# Altium schematic sheet mcu_side.SchDoc
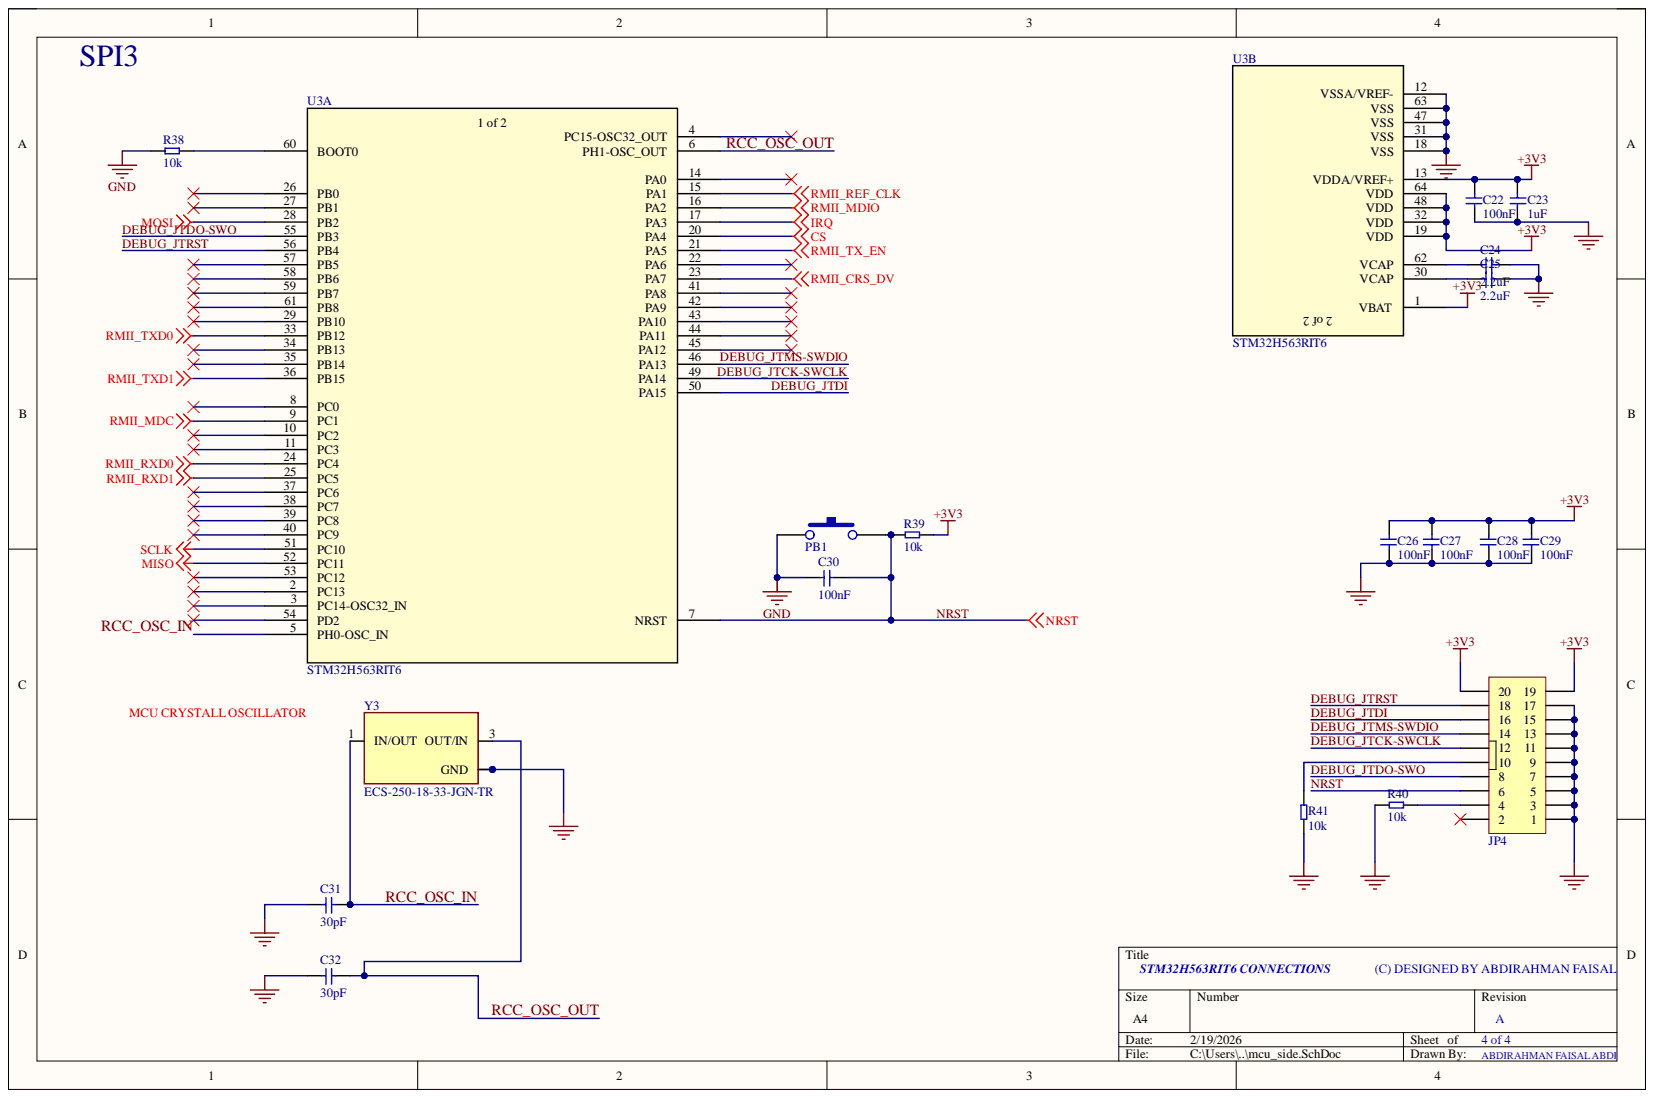
[diagram p0: mcu_side.SchDoc]

## Parts
C22  100nF  [C0603]
C23  1uF  [C0603]
C24  2.2uF  [C0603]
C25  2.2uF  [C0603]
C26  100nF  [C0603]
C27  100nF  [C0603]
C28  100nF  [C0603]
C29  100nF  [C0603]
C30  100nF  [C0603]
C31  30pF  [C0603]
C32  30pF  [C0603]
JP4  Box_Header 2X10  [Box_Header 2x10]  (value not drawn)
PB1  PUSH_BUTTON  [Push Button SPST]  (value not drawn)
R38  10k  [R0603]
R39  10k  [R0603]
R40  10k  [R0603]
R41  10k  [R0603]
U3  STM32H563RIT6  [LQFP64-10x10mm_STM32H563RIT6]  (parts A,B)
Y3  ECS-250-18-33-JGN-TR  [XTAL_ECS-250-18-33-JGN-TR]

## Nets
+3V3: C22.1 C23.2 C26.1 C27.1 C28.1 C29.1 JP4.19 JP4.20 R39.2 U3.1(VBAT) U3.13(VDDA/VREF+) U3.19(VDD) U3.32(VDD) U3.48(VDD) U3.64(VDD)
CS: U3.20(PA4)
GND: C22.2 C23.1 C24.2 C25.2 C26.2 C27.2 C28.2 C29.2 C30.1 C31.1 C32.1 JP4.1 JP4.3 JP4.5 JP4.7 JP4.9 JP4.11 JP4.13 JP4.15 JP4.17 PB1.1 R38.1 R40.1 R41.1 U3.12(VSSA/VREF-) U3.18(VSS) U3.31(VSS) U3.47(VSS) U3.63(VSS) Y3.2(GND) Y3.4
IRQ: U3.17(PA3)
MISO: U3.52(PC11)
MOSI: U3.28(PB2)
RMII_CRS_DV: U3.23(PA7)
RMII_MDC: U3.9(PC1)
RMII_MDIO: U3.16(PA2)
RMII_REF_CLK: U3.15(PA1)
RMII_RXD0: U3.24(PC4)
RMII_RXD1: U3.25(PC5)
RMII_TX_EN: U3.21(PA5)
RMII_TXD0: U3.33(PB12)
RMII_TXD1: U3.36(PB15)
SCLK: U3.51(PC10)
DEBUG_JTCK-SWCLK: JP4.12 U3.49(PA14)
DEBUG_JTDI: JP4.16 U3.50(PA15)
DEBUG_JTDO-SWO: JP4.8 U3.55(PB3)
DEBUG_JTMS-SWDIO: JP4.14 U3.46(PA13)
DEBUG_JTRST: JP4.18 U3.56(PB4)
NRST: C30.2 JP4.6 PB1.2 R39.1 U3.7(NRST)
RCC_OSC_IN: C31.2 U3.5(PH0-OSC_IN) Y3.1(IN/OUT)
RCC_OSC_OUT: C32.2 U3.6(PH1-OSC_OUT) Y3.3(OUT/IN)
NetC24_1: C24.1 U3.62(VCAP)
NetC25_1: C25.1 U3.30(VCAP)
NetJP4_4: JP4.4 R40.2
NetJP4_10: JP4.10 R41.2
NetR38_2: R38.2 U3.60(BOOT0)

Not connected: JP4 2; U3 2(PC13) 3(PC14-OSC32_IN) 4(PC15-OSC32_OUT) 8(PC0) 10(PC2) 11(PC3) 14(PA0) 22(PA6) 26(PB0) 27(PB1) 29(PB10) 34(PB13) 35(PB14) 37(PC6) 38(PC7) 39(PC8) 40(PC9) 41(PA8) 42(PA9) 43(PA10) 44(PA11) 45(PA12) 53(PC12) 54(PD2) 57(PB5) 58(PB6) 59(PB7) 61(PB8)

## Library data of the parts
C22 C26-C30 = CGA3E3X8R1H104K080AD: CAP CER 0.1UF 50V X8R 0603 EPOXY; Manufacturer: TDK; Category: Ceramic Capacitors; Component Kind: Standard; ComponentLink1Description: https://datasheet.ciiva.com/24219/cga3e3x8r1h104k080ad-270768-24219691.pdf?src-supplier=Mouser; ComponentLink1URL: https://datasheet.ciiva.com/24219/cga3e3x8r1h104k080ad-270768-24219691.pdf?src-supplier=Mouser; LCSC part number: C2171928; Manufacturer Part Number: CGA3E3X8R1H104K080AD; Pricing 1: 1=0.22, 10=0.129, 50=0.0922, 100=0.0693, 500=0.06232, 1000=0.05656 (USD); RoHS: Yes; Stock 1: 30979; Supplier 1: Digikey
  C22 C26-C29: Library Name: IO.SchLib
  C30: Library Name: arfaisal.IntLib
C23 = CL10B105KP8NNNC: CAP CER 1UF 10V X7R 0603; Manufacturer: Samsung; Tolerance: 10%; Capacitance: 1uF; Case Code (Imperial): 0603; Case Code (Metric): 1608; Case/Package: 0603; Category: Ceramic Capacitors; Component Kind: Standard; ComponentLink1Description: https://datasheet.ciiva.com/25788/cl10b105kp8nnnc-25788304.pdf?src-supplier=Digikey; ComponentLink1URL: https://datasheet.ciiva.com/25788/cl10b105kp8nnnc-25788304.pdf?src-supplier=Digikey; ComponentLink2Description: https://datasheet.ciiva.com/16396/cl10b105kp8nnnc-16396970.pdf?src-supplier=Future+Electronics; ComponentLink2URL: https://datasheet.ciiva.com/16396/cl10b105kp8nnnc-16396970.pdf?src-supplier=Future+Electronics; ComponentLink3Description: https://datasheet.ciiva.com/26838/getdatasheetpartid-358410-26838426.pdf?src-supplier=Verical; ComponentLink3URL: https://datasheet.ciiva.com/26838/getdatasheetpartid-358410-26838426.pdf?src-supplier=Verical; Construction: Flat; Depth: 0.8mm; Dielectric: X7R; Height: 0.8mm; LCSC part number: C95843; Lead Free: Lead Free; Length: 1.6mm; Manufacturer Part Number: CL10B105KP8NNNC; Max Operating Temperature: 125°C; Min Operating Temperature: -55°C; Mount: Surface Mount; Package Quantity: 1; Packaging: Tape and Reel; Pricing 1: 1=0.08, 10=0.005 (USD); Radiation Hardening: No; RoHS: Yes; RoHS Compliant: Yes; Series: CL; Stock 1: 5099033; Supplier 1: Digikey; Thickness: 0.035inch; Voltage Rating: 10V; Voltage Rating (DC): 10V; Width: 0.031inch; Library Name: arfaisal.IntLib
C24 C25 = CC0603KRX7R6BB225: Multilayer Ceramic Capacitor, 2.2 uF, 10 V, ± 10%, X7R, 0603 [1608 Metric]; Manufacturer: Yageo; Material: 4241ConductorMaterial; Voltage Rating: 10V; Capacitance: 2.2uF; Case Code (Imperial): 0603; Case Code (Metric): 1608; Case/Package: 0603; Category: Ceramic Capacitors; Component Kind: Standard; ComponentLink1Description: https://datasheet.ciiva.com/13210/upy-gphc-x7r-6-3v-to-50v-8-12304-13210987.pdf?src-supplier=Mouser; ComponentLink1URL: https://datasheet.ciiva.com/13210/upy-gphc-x7r-6-3v-to-50v-8-12304-13210987.pdf?src-supplier=Mouser; Dielectric: X7R; Halogen Free: Halogen Free; LCSC part number: C513691; Lead Free: Lead Free; Length: 1.6mm; Manufacturer Part Number: CC0603KRX7R6BB225; Max Operating Temperature: 125°C; Min Operating Temperature: -55°C; Mount: Surface Mount; Number of Pins: 2NUMBER; Packaging: Tape and Reel; Pricing 1: 1=0.14, 10=0.079, 50=0.0552, 100=0.0429, 500=0.03596, 1000=0.03223 (USD); RoHS: Yes; RoHS Compliant: Yes; Series: CC; Stock 1: 14335; Supplier 1: Digikey; Termination: SMD/SMT; Tolerance: 10%; Voltage Rating (DC): 10V; Width: 0.8mm; Library Name: ARFEISAL.SchLib
C31 C32 = AC0603JRNPO9BN300: CAP CER 30PF 50V C0G/NPO 0603; Manufacturer: Yageo; Category: Ceramic Capacitors; Component Kind: Standard; LCSC part number: C2191217; Library Name: IO.SchLib; Manufacturer Part Number: AC0603JRNPO9BN300; Pricing 1: 1=0.11, 10=0.061, 50=0.0424, 100=0.035, 500=0.02706, 1000=0.02316 (USD); RoHS: No; Stock 1: 2686; Supplier 1: Digikey
JP4 = Box_Header 2X10: Header, 10-Pin, Dual row; Component Kind: Standard; Published: 28-May-2008; Library Name: UTM_RBC_20241.IntLib; Publisher: UTM ROBOCON
PB1 = PB: Component Kind: Standard; Library Name: arfaisal.IntLib
R38-R41 = RT0603FRE0710KL: Fixed Resistor, Thin Film, 0.1W, 10000ohm, 75V, 1% +/-Tol, 50ppm/Cel, Surface Mount, 0603; Manufacturer: Yageo; Max Power Dissipation: 100mW; Resistance: 10kR; Temperature Coefficient: 50ppm/°C; Case Code (Imperial): 0603; Case Code (Metric): 1608; Case/Package: 0603; Category: Chip SMD Resistors; Component Kind: Standard; ComponentLink1Description: https://datasheet.ciiva.com/12694/pyu-rt-1-to-0-05-rohs-l-4-12694910.pdf?src-supplier=Mouser; ComponentLink1URL: https://datasheet.ciiva.com/12694/pyu-rt-1-to-0-05-rohs-l-4-12694910.pdf?src-supplier=Mouser; ComponentLink2Description: https://datasheet.ciiva.com/16745/pyu-rt-1-to-0-05-rohs-l-4-16745739.pdf?src-supplier=Future+Electronics; ComponentLink2URL: https://datasheet.ciiva.com/16745/pyu-rt-1-to-0-05-rohs-l-4-16745739.pdf?src-supplier=Future+Electronics; ComponentLink3Description: https://datasheet.ciiva.com/2559/pyu-rt-1-to-0-05-rohs-l-5-2559482.pdf?src-supplier=Digikey; ComponentLink3URL: https://datasheet.ciiva.com/2559/pyu-rt-1-to-0-05-rohs-l-5-2559482.pdf?src-supplier=Digikey; Contact Plating: Tin,Lead; Features: Moisture Resistant; Height: 0.022inch; LCSC part number: C469659; Lead Free: 5697LeadFree; Length: 0.063inch; Manufacturer Part Number: RT0603FRE0710KL; Max Operating Temperature: 125°C; Min Operating Temperature: -55°C; Number of Pins: 2; Number of Terminations: 2; Package Quantity: 5000; Packaging: Tape and Reel; Power Rating: 100mW; Pricing 1: 1=0.1, 10=0.012, 25=0.0116, 50=0.0112, 100=0.0107, 250=0.0102 (USD); Reach SVHC Compliant: Unknown; Resistance Tolerance: 1%; Resistor Type: Thin Film; RoHS: Yes; RoHS Compliant: Yes; Series: RT; Size Code: 0603; Stock 1: 237229; Supplier 1: Digikey; Termination: SMD/SMT; Tolerance: 1%; Voltage Rating: 75V; Voltage Rating (DC): 75V; Width: 0.031inch; Library Name: arfaisal.IntLib
U3 = STM32H563RIT6: High-performance, Arm Cortex-M33 with TrustZone, MCU with 2-MByte Flash, 640-Kbyte RAM, 250 MHz CPU; Manufacturer_Name: STMicroelectronics; Component Kind: Standard; ComponentLink1Description: New link; Datasheet: https://www.st.com/resource/en/datasheet/stm32h562ag.pdf; LCSC part number: C20619634; Manufacturer_Part_Number: STM32H563RIT6; RefDes: RefDes; Type: Type; Copyright: Copyright (C) 2025 Ultra Librarian. All rights reserved.; Library Name: arfaisal.IntLib
Y3 = ECS-250-18-33-JGN-TR (2): CRYSTAL 25.0000MHZ 18PF SMD; Manufacturer: ECS International; ESR (Equivalent Series Resistance): 40R; Frequency: 25MHz; Frequency Stability: 30ppm; Frequency Tolerance: 20ppm; Case/Package: SMD/SMT; Category: Crystals; Component Kind: Standard; ComponentLink1Description: https://www.ecsxtal.com/store/pdf/ecx-32.pdf?src-supplier=Digikey; ComponentLink1URL: https://www.ecsxtal.com/store/pdf/ecx-32.pdf?src-supplier=Digikey; ComponentLink2Description: https://datasheet.octopart.com/ECS-250-18-33-JGN-TR-ECS-International-datasheet-140089731.pdf?src-supplier=Future+Electronics; ComponentLink2URL: https://datasheet.octopart.com/ECS-250-18-33-JGN-TR-ECS-International-datasheet-140089731.pdf?src-supplier=Future+Electronics; ComponentLink3Description: https://datasheet.octopart.com/ECS-250-18-33-JGN-TR-ECS-International-datasheet-17018741.pdf?src-supplier=Electro+Sonic; ComponentLink3URL: https://datasheet.octopart.com/ECS-250-18-33-JGN-TR-ECS-International-datasheet-17018741.pdf?src-supplier=Electro+Sonic; ComponentLink4Description: https://datasheet.octopart.com/ECS-250-18-33-JGN-TR-ECS-International-datasheet-179230830.pdf?src-supplier=Master+Electronics; ComponentLink4URL: https://datasheet.octopart.com/ECS-250-18-33-JGN-TR-ECS-International-datasheet-179230830.pdf?src-supplier=Master+Electronics; Library Name: IO.SchLib; Load Capacitance: 18pF; Manufacturer Part Number: ECS-250-18-33-JGN-TR; Max Operating Temperature: 85°C; Min Operating Temperature: -40°C; Mount: Surface Mount; Packaging: Cut Tape; Pricing 1: 1=0.41, 10=0.355, 25=0.336, 50=0.3222, 100=0.3089, 250=0.29208, 500=0.28 (USD); RoHS: Yes; RoHS Compliant: Yes; Series: ECX-32; Stock 1: 39748; Supplier 1: Digikey
